# Altium schematic sheet bolid-power-dcdc-ldo.SchDoc (continued)

## Library data of the parts
C5 C7 C24 C31 = EMK107BB7225MA-T: MLCC 0603 (1608) 2.2uF 20% 16V X7R; Brand: Taiyo Yuden; Capacitance - nF: 2200 nF; Type: AECStandardQ200; Value: 2.2u; Voltage Rating DC: 16 VDC; Capacitance: 2.2 uF; Case Code - in: 0603; Case Code - mm: 1608; Category: Multilayer Ceramic Capacitors MLCC - SMD/SMT; Component Kind: Standard; ComponentLink1Description: https://www.mouser.com/datasheet/2/396/mlcc02_e-1307760.pdf; ComponentLink1URL: https://www.mouser.com/datasheet/2/396/mlcc02_e-1307760.pdf; Dielectric: X7R; Height: 0.8 mm; Length: 1.6 mm; Library Name: capacitors2.IntLib; Manufacturer: Taiyo Yuden; Manufacturer Part Number: EMK107BB7225MA-T; Maximum Operating Temperature: + 125 C; Minimum Operating Temperature: - 55 C; Minimum Order Quantity: 1; Package / Case: 0603 (1608 metric); Packaging: Cut Tape; Pricing 1: 1=0.324, 10=0.228, 100=0.129, 500=0.090, 1000=0.078, 4000=0.060, 8000=0.057, 12000=0.055, 24000=0.048 (EUR); Product: Automotive MLCCs; Product Type: Ceramic Capacitors; Reeling: True; RoHS: RoHS Compliant; RoHS - Mouser: Y; Series: M; Signal Integrity: Cap Semi; Simulation: CAP; Standard Pack Qty: 4000; Stock 1: 5687; Subcategory: Capacitors; Supplier 1: Mouser; Supplier Part Number 1: 963-EMK107BB7225MA-T; Termination: Standard; Termination Style: SMD/SMT; Tolerance: 20 %; Width: 0.8 mm
C6 C16 C26 C29 C32 = GRM155R71H104KE14D: MLCC 0402 (1005) 100nF 10% 50V X7R; Brand: Murata Electronics; Capacitance: 0.1 uF; Capacitance - pF: 100000 pF; Class: Class 2; Type: Chip Multilayer Ceramic Capacitor for General Purpose; Voltage Rating DC: 50 VDC; Capacitance - nF: 100 nF; Case Code - in: 0402; Case Code - mm: 1005; Category: Multilayer Ceramic Capacitors MLCC - SMD/SMT; Component Kind: Standard; ComponentLink1Description: https://www.mouser.com/datasheet/2/281/murata_03052018_GRM_Series_1-1310166.pdf; ComponentLink1URL: https://www.mouser.com/datasheet/2/281/murata_03052018_GRM_Series_1-1310166.pdf; Dielectric: X7R; Height: 0.5 mm; Length: 1 mm; Library Name: capacitors2.IntLib; Manufacturer: Murata Electronics; Manufacturer Part Number: GRM155R71H104KE14D; Maximum Operating Temperature: + 125 C; Minimum Operating Temperature: - 55 C; Minimum Order Quantity: 1; Package / Case: 0402 (1005 metric); Packaging: Cut Tape; Pricing 1: 1=0.090, 10=0.038, 100=0.016, 500=0.012, 1000=0.009, 2500=0.008, 5000=0.007, 10000=0.005 (EUR); Product: General Type MLCCs; Product Type: Ceramic Capacitors; Reeling: True; RoHS: RoHS Compliant; RoHS - Mouser: Y; Series: GRM; Signal Integrity: Cap Semi; Simulation: CAP; Standard Pack Qty: 10000; Stock 1: 3511637; Subcategory: Capacitors; Supplier 1: Mouser; Supplier Part Number 1: 81-GRM155R71H104KE4D; Termination: Standard; Termination Style: SMD/SMT; Tolerance: 10 %; Value Displayed: 100nF; Width: 0.5 mm
C10 = GRM155R71A474KE01D: MLCC 0402 (1005) 470nF 10% 10V X7R; Brand: Murata Electronics; Capacitance: 0.47 uF; Capacitance - pF: 470000 pF; Class: Class 2; Type: Chip Multilayer Ceramic Capacitor for General Purpose; Value: 470n; Voltage Rating DC: 10 VDC; Capacitance - nF: 470 nF; Case Code - in: 0402; Case Code - mm: 1005; Category: Multilayer Ceramic Capacitors MLCC - SMD/SMT; Component Kind: Standard; ComponentLink1Description: https://www.mouser.com/datasheet/2/281/murata_03052018_GRM_Series_1-1310166.pdf; ComponentLink1URL: https://www.mouser.com/datasheet/2/281/murata_03052018_GRM_Series_1-1310166.pdf; Dielectric: X7R; Height: 0.5 mm; Length: 1 mm; Library Name: capacitors2.IntLib; Manufacturer: Murata Electronics; Manufacturer Part Number: GRM155R71A474KE01D; Maximum Operating Temperature: + 125 C; Minimum Operating Temperature: - 55 C; Minimum Order Quantity: 1; Package / Case: 0402 (1005 metric); Packaging: Cut Tape; Pricing 1: 1=0.090, 10=0.064, 100=0.028, 500=0.020, 1000=0.015, 2500=0.014, 5000=0.013, 10000=0.010, 20000=0.009 (EUR); Product: General Type MLCCs; Product Type: Ceramic Capacitors; Reeling: True; RoHS: RoHS Compliant; RoHS - Mouser: Y; Series: GRM; Signal Integrity: Cap Semi; Simulation: CAP; Standard Pack Qty: 10000; Stock 1: 1591702; Subcategory: Capacitors; Supplier 1: Mouser; Supplier Part Number 1: 81-GRM155R71A474KE1D; Termination: Standard; Termination Style: SMD/SMT; Tolerance: 10 %; Width: 0.5 mm
C11-C15 C25 C27 C28 = C1210C106K5RACTU: MLCC 1210 (3225) 10uF 10% 50V X7R; Brand: KEMET; Class: Class 2; Voltage Rating DC: 50 VDC; Capacitance: 10 uF; Case Code - in: 1210; Case Code - mm: 3225; Category: Multilayer Ceramic Capacitors MLCC - SMD/SMT; Component Kind: Standard; ComponentLink1Description: https://www.mouser.com/datasheet/2/212/KEM_C1002_X7R_SMD-1102033.pdf; ComponentLink1URL: https://www.mouser.com/datasheet/2/212/KEM_C1002_X7R_SMD-1102033.pdf; Dielectric: X7R; Height: 2.5 mm; Length: 3.2 mm; Library Name: capacitors2.IntLib; Manufacturer: KEMET; Manufacturer Part Number: C1210C106K5RACTU; Maximum Operating Temperature: + 125 C; Minimum Operating Temperature: - 55 C; Minimum Order Quantity: 1; Package / Case: 1210 (3225 metric); Packaging: Cut Tape; Pricing 1: 1=0.984, 10=0.728, 100=0.498, 500=0.394, 1000=0.296 (USD); Product: General Type MLCCs; Product Type: Ceramic Capacitors; Reeling: True; RoHS: RoHS Compliant; RoHS - Mouser: Y; Series: SMD Comm X7R; Signal Integrity: Cap Semi; Simulation: CAP; Standard Pack Qty: 1000; Stock 1: 1149; Subcategory: Capacitors; Supplier 1: Mouser; Supplier Part Number 1: 80-C1210C106K5R; Termination: Standard; Termination Style: SMD/SMT; Tolerance: 10 %; Value Displayed: 10uF; Voltage Rating AC: -; Width: 2.5 mm
C17 C21 C22 = EMK325BJ476MM-P: MLCC 1210 (3225) 47.0uF 20% 16V X5R; Brand: Taiyo Yuden; Capacitance: 47 uF; Type: Multilayer Ceramic Capacitors; Value: 47u; Voltage Rating DC: 16 VDC; Case Code - in: 1210; Case Code - mm: 3225; Category: Multilayer Ceramic Capacitors MLCC - SMD/SMT; Component Kind: Standard; ComponentLink1Description: https://www.mouser.com/datasheet/2/396/mlcc02_e-1307760.pdf; ComponentLink1URL: https://www.mouser.com/datasheet/2/396/mlcc02_e-1307760.pdf; Dielectric: X5R; Height: 2.5 mm; Length: 3.2 mm; Library Name: capacitors2.IntLib; Manufacturer: Taiyo Yuden; Manufacturer Part Number: EMK325BJ476MM-P; Maximum Operating Temperature: + 85 C; Minimum Operating Temperature: - 55 C; Minimum Order Quantity: 1; Package / Case: 1210 (3225 metric); Packaging: Cut Tape; Pricing 1: 1=0.639, 10=0.396, 100=0.266, 500=0.224, 1000=0.180, 2000=0.167, 5000=0.156, 10000=0.135, 25000=0.131 (EUR); Product: General Type MLCCs; Product Type: Ceramic Capacitors; Reeling: True; RoHS: RoHS Compliant; RoHS - Mouser: Y; Series: M; Signal Integrity: Cap Semi; Simulation: CAP; Standard Pack Qty: 1000; Stock 1: 61399; Subcategory: Capacitors; Supplier 1: Mouser; Supplier Part Number 1: 963-EMK325BJ476MM-P; Termination: Standard; Termination Style: SMD/SMT; Tolerance: 20 %; Width: 2.5 mm
C18 = GCM1555C1H220JA16D: MLCC 0402 (1005) 22pF 5% 50V C0G (NP0); Brand: Murata Electronics; Class: Class 1; Qualification: AEC-Q200; Type: Chip Multilayer Ceramic Capacitors for Automotive; Value: 22p; Voltage Rating DC: 50 VDC; Capacitance: 22 pF; Case Code - in: 0402; Case Code - mm: 1005; Category: Multilayer Ceramic Capacitors MLCC - SMD/SMT; Component Kind: Standard; ComponentLink1Description: https://www.mouser.com/datasheet/2/281/GCM1555C1H220JA16_01-1964700.pdf; ComponentLink1URL: https://www.mouser.com/datasheet/2/281/GCM1555C1H220JA16_01-1964700.pdf; Dielectric: C0G (NP0); Height: 0.5 mm; Length: 1 mm; Library Name: capacitors2.IntLib; Manufacturer: Murata Electronics; Manufacturer Part Number: GCM1555C1H220JA16D; Maximum Operating Temperature: + 125 C; Minimum Operating Temperature: - 55 C; Minimum Order Quantity: 1; Package / Case: 0402 (1005 metric); Packaging: Cut Tape; Pricing 1: 1=0.085, 10=0.026, 100=0.022, 500=0.015, 1000=0.013, 2500=0.011, 5000=0.010, 10000=0.008 (EUR); Product: Automotive MLCCs; Product Type: Ceramic Capacitors; Reeling: True; RoHS: RoHS Compliant; RoHS - Mouser: Y; Series: GCM; Signal Integrity: Cap Semi; Simulation: CAP; Standard Pack Qty: 10000; Stock 1: 193169; Subcategory: Capacitors; Supplier 1: Mouser; Supplier Part Number 1: 81-GCM1555C1H220JA6D; Termination: Standard; Termination Style: SMD/SMT; Tolerance: 5 %; Voltage Rating AC: -; Width: 0.5 mm
C19 C20 = T495X476K035ATE300: TANT 2917 (7343) 47uF 10% 35V Tantalum Kemet Case X; Mfr Case Code: X Case; ESR: 300 mOhms; Type: SMD Automotive Grade Surge Robust Low ESR; Voltage Rating DC: 35 VDC; Brand: KEMET; Capacitance: 47 uF; Case Code - in: 2917; Case Code - mm: 7343; Category: Tantalum Capacitors - Solid SMD; Component Kind: Standard; ComponentLink1Description: https://www.mouser.com/datasheet/2/212/KEM_T2009_T495-1092841.pdf; ComponentLink1URL: https://www.mouser.com/datasheet/2/212/KEM_T2009_T495-1092841.pdf; Height: 4 mm; Length: 7.3 mm; Library Name: capacitors2.IntLib; Manufacturer: KEMET; Manufacturer Part Number: T495X476K035ATE300; Maximum Operating Temperature: + 125 C; Minimum Operating Temperature: - 55 C; Minimum Order Quantity: 1; Packaging: Cut Tape; Pricing 1: 1=2.42, 10=1.94, 100=1.46, 500=0.989, 1000=0.897, 2500=0.884 (USD); Product: Tantalum Solid Low ESR Automotive Grade; Product Type: Tantalum Capacitors; Reeling: True; RoHS: RoHS Compliant; RoHS - Mouser: Y; Series: T495; Signal Integrity: Cap Semi; Simulation: CAP; Standard Pack Qty: 500; Stock 1: 39161; Subcategory: Capacitors; Supplier 1: Mouser; Supplier Part Number 1: 80-T495X476K35ATE300; Termination Style: SMD/SMT; Tolerance: 10 %; Value: 47uF; Width: 4.3 mm
C23 = C1005X5R1A475M050BC: MLCC 0402 (1005) 4.7uF 20% 10V X5R; Brand: TDK; Capacitance - nF: 4700 nF; Class: Class2; Type: Multilayer Ceramic Chip Capacitor; Value: 4.7u; Voltage Rating DC: 10 VDC; Capacitance: 4.7 uF; Case Code - in: 0402; Case Code - mm: 1005; Category: Multilayer Ceramic Capacitors MLCC - SMD/SMT; Component Kind: Standard; Dielectric: X5R; Height: 0.5 mm; Length: 1 mm; Library Name: capacitors2.IntLib; Manufacturer: TDK; Manufacturer Part Number: C1005X5R1A475M050BC; Maximum Operating Temperature: + 85 C; Minimum Operating Temperature: - 55 C; Minimum Order Quantity: 1; Packaging: Cut Tape; Pricing 1: 1=0.398, 10=0.234, 100=0.170, 500=0.130, 1000=0.109, 2500=0.103, 5000=0.100, 10000=0.097 (EUR); Product: General Type MLCCs; Product Type: Ceramic Capacitors; Reeling: True; RoHS: RoHS Compliant; RoHS - Mouser: Y; Series: C; Signal Integrity: Cap Semi; Simulation: CAP; Standard Pack Qty: 10000; Stock 1: 236282; Subcategory: Capacitors; Supplier 1: Mouser; Supplier Part Number 1: 810-C1005X5R1A475M; Termination: Standard; Termination Style: SMD/SMT; Tolerance: 20 %; Voltage Rating AC: -; Width: 0.5 mm
C30 = TMK316AB7106KL-T: MLCC 1206 (3216) 10uF 10% 25V X7R; Brand: Taiyo Yuden; Class: Class 2; Type: General Purpose; Value: 10u; Voltage Rating DC: 25 VDC; Capacitance: 10 uF; Case Code - in: 1206; Case Code - mm: 3216; Category: Multilayer Ceramic Capacitors MLCC - SMD/SMT; Component Kind: Standard; ComponentLink1Description: https://www.mouser.com/datasheet/2/396/mlcc02_e-1307760.pdf; ComponentLink1URL: https://www.mouser.com/datasheet/2/396/mlcc02_e-1307760.pdf; Diameter: -; Dielectric: X7R; Height: 1.6 mm; Length: 3.2 mm; Library Name: capacitors2.IntLib; Manufacturer: Taiyo Yuden; Manufacturer Part Number: TMK316AB7106KL-T; Maximum Operating Temperature: + 125 C; Minimum Operating Temperature: - 55 C; Minimum Order Quantity: 1; Package / Case: 1206 (3216 metric); Packaging: Cut Tape; Pricing 1: 1=0.288, 10=0.130, 100=0.087, 500=0.077, 1000=0.068, 2000=0.056 (EUR); Product: General Type MLCCs; Product Type: Ceramic Capacitors; Reeling: True; RoHS: RoHS Compliant; RoHS - Mouser: Y; Series: M; Signal Integrity: Cap Semi; Simulation: CAP; Standard Pack Qty: 2000; Stock 1: 162457; Subcategory: Capacitors; Supplier 1: Mouser; Supplier Part Number 1: 963-TMK316AB7106KL-T; Termination: Standard; Termination Style: SMD/SMT; Tolerance: 10 %; Width: 1.6 mm
C33-C37 = GRM21BR71A106KA73K: MLCC 0805 (2012) 10uF 10% 10V X7R; Brand: Murata Electronics; Class: Class 2; Type: Chip Multilayer Ceramic Capacitor for General Purpose; Value: 10u; Voltage Rating DC: 10 VDC; Capacitance: 10 uF; Case Code - in: 0805; Case Code - mm: 2012; Category: Multilayer Ceramic Capacitors MLCC - SMD/SMT; Component Kind: Standard; ComponentLink1Description: https://www.mouser.com/datasheet/2/281/murata_03052018_GRM_Series_1-1310166.pdf; ComponentLink1URL: https://www.mouser.com/datasheet/2/281/murata_03052018_GRM_Series_1-1310166.pdf; Dielectric: X7R; Height: 1.25 mm; Length: 2 mm; Library Name: capacitors2.IntLib; Manufacturer: Murata Electronics; Manufacturer Part Number: GRM21BR71A106KA73K; Maximum Operating Temperature: + 125 C; Minimum Operating Temperature: - 55 C; Minimum Order Quantity: 1; Package / Case: 0805 (2012 metric); Packaging: Cut Tape; Pricing 1: 1=0.207, 10=0.144, 100=0.072, 500=0.050, 1000=0.043, 2500=0.040, 5000=0.036, 10000=0.030, 20000=0.028 (EUR); Product: General Type MLCCs; Product Type: Ceramic Capacitors; Reeling: True; RoHS: RoHS Compliant; RoHS - Mouser: Y; Series: GRM; Signal Integrity: Cap Semi; Simulation: CAP; Standard Pack Qty: 10000; Stock 1: 108729; Subcategory: Capacitors; Supplier 1: Mouser; Supplier Part Number 1: 81-GRM21BR71A106KA3K; Termination: Standard; Termination Style: SMD/SMT; Tolerance: 10 %; Width: 1.25 mm
D2-D5 = 150060GS75000: LED 0603 (1608) Green; Brand: Wurth Elektronik; If - Forward Current: 20 mA; Lens Color/Style: Water Clear; Lens Shape: Rectangular; Luminous Intensity: 430 mcd; Peak Wavelength: 515 nm; Power Rating: 105 mW; Vf - Forward Voltage: 3.2 V; Viewing Angle: 140 deg; Vr - Reverse Voltage: 5 V; Wavelength/Color Temperature: 520 nm; Category: Standard LEDs - SMD; Component Kind: Standard; ComponentLink1Description: https://www.mouser.com/datasheet/2/445/150060GS75000-368921.pdf; ComponentLink1URL: https://www.mouser.com/datasheet/2/445/150060GS75000-368921.pdf; Height: 0.7 mm; Length: 1.6 mm; Lens Dimensions: 1.1 mm x 0.8 mm; Lens Transparency: Water Clear; Library Name: semiconductors2.IntLib; Manufacturer: Wurth Elektronik; Manufacturer Part Number: 150060GS75000; Maximum Operating Temperature: + 85 C; Minimum Operating Temperature: - 40 C; Minimum Order Quantity: 1; Moisture Sensitive: Yes; Mounting Style: SMD/SMT; Package / Case: 0603 (1608 metric); Packaging: Cut Tape; Pricing 1: 1=0.130, 10=0.126, 50=0.115, 100=0.099, 500=0.085, 4000=0.085 (EUR); Product: Green LEDs; Product Type: LED - Standard; Reeling: True; RoHS: RoHS Compliant; RoHS - Mouser: Y; Series: WL-SMCW; Signal Integrity: Diode; Simulation: DIODE; Standard Pack Qty: 4000; Stock 1: 17312; Subcategory: LEDs; Supplier 1: Mouser; Supplier Part Number 1: 710-150060GS75000; Width: 0.8 mm
D17 = VSSAF5L45HM3_A/H: Schottky Rectifier Diode, 5A, Do-221Ac-2 Rohs Compliant: Yes |Vishay VSSAF5L45HM3_A/H; Manufacturer: Vishay; Category: Rectifier Diodes; Component Kind: Standard; Library Name: semiconductors2.IntLib; Manufacturer Part Number: VSSAF5L45HM3_A/H; Pricing 1: 1=0,45, 10=0,303, 50=0,303, 100=0,205, 1000=0,142, 10000=0,115 (USD); RoHS: No; RoHS Compliant: Yes; Signal Integrity: Diode; Simulation: DIODE; Stock 1: 4251; Supplier 1: Mouser; Supplier Part Number 1: 78-VSSAF5L45HM3_A/H
D18 = BZX84-B3V6,215: Zener Diode Single 3.6V 2% 90Ohm 250mW Automotive 3-Pin SOT-23 T/R; Manufacturer: NXP Semiconductors; Continuous Drain Current (ID): -150mA; Drain to Source Voltage (Vdss): -50V; ESD Protection: No; Impedance: 90R; Max Reverse Leakage Current: 5uA; Test Current: 5mA; Threshold Voltage: -1.6V; Case/Package: TO-236-3; Category: Uncategorized; Component Kind: Standard; ComponentLink1Description: https://datasheet.ciiva.com/10645/bzx84-series-10645035.pdf?src-supplier=Avnet; ComponentLink1URL: https://datasheet.ciiva.com/10645/bzx84-series-10645035.pdf?src-supplier=Avnet; ComponentLink2Description: https://datasheet.ciiva.com/890/bzx84-ser-890061.pdf?src-supplier=Digi-Key; ComponentLink2URL: https://datasheet.ciiva.com/890/bzx84-ser-890061.pdf?src-supplier=Digi-Key; ComponentLink3Description: https://datasheet.ciiva.com/890/bzx84-ser-890063.pdf?src-supplier=Digi-Key; ComponentLink3URL: https://datasheet.ciiva.com/890/bzx84-ser-890063.pdf?src-supplier=Digi-Key; ComponentLink4Description: https://datasheet.ciiva.com/890/bzx84-series-890064.pdf?src-supplier=Digi-Key; ComponentLink4URL: https://datasheet.ciiva.com/890/bzx84-series-890064.pdf?src-supplier=Digi-Key; ComponentLink5Description: https://datasheet.ciiva.com/8347/bzx84-series-8347141.pdf?src-supplier=Avnet; ComponentLink5URL: https://datasheet.ciiva.com/8347/bzx84-series-8347141.pdf?src-supplier=Avnet; ComponentLink6Description: https://datasheet.ciiva.com/17265/bzx84-series-17265675.pdf?; ComponentLink6URL: https://datasheet.ciiva.com/17265/bzx84-series-17265675.pdf?; Contact Plating: Tin; Element Configuration: Single; Lead Free: Lead Free; Library Name: semiconductors2.IntLib; Manufacturer Part Number: BZX84-B3V6,215; Max Operating Temperature: 150°C; Max Power Dissipation: 250mW; Min Operating Temperature: -65°C; Mount: Surface Mount; Number of Pins: 3; Package Quantity: 1; Packaging: Cut Tape; Power Dissipation: 250mW; Radiation Hardening: No; Reach SVHC Compliant: Yes; RoHS: Yes; RoHS Compliant: Yes; Supplier 1: Mouser; Supplier Part Number 1: 771-BZX84-B3V6215; Tolerance: 2%; Voltage Tolerance: 2%
D19 D21 D22 = MBR0530T3G: ON Semi MBR0530T3G; SMT Schottky Diode; 30V 500mA; 2-Pin SOD-123; Manufacturer: ON Semiconductor; Category: Schottky Diodes; Component Kind: Standard; ComponentLink1Description: https://datasheet.ciiva.com/6381/mbr0530t1-d-6381904.pdf?src-supplier=Digikey; ComponentLink1URL: https://datasheet.ciiva.com/6381/mbr0530t1-d-6381904.pdf?src-supplier=Digikey; ComponentLink2Description: https://datasheet.ciiva.com/7962/85890-7962703.pdf?src-supplier=Element14; ComponentLink2URL: https://datasheet.ciiva.com/7962/85890-7962703.pdf?src-supplier=Element14; ComponentLink3Description: https://datasheet.ciiva.com/8294/mbr0530t1-d-8294572.pdf?src-supplier=Avnet; ComponentLink3URL: https://datasheet.ciiva.com/8294/mbr0530t1-d-8294572.pdf?src-supplier=Avnet; ComponentLink4Description: https://datasheet.ciiva.com/15144/getdatasheetpartid-86762-15144599.pdf?src-supplier=Verical; ComponentLink4URL: https://datasheet.ciiva.com/15144/getdatasheetpartid-86762-15144599.pdf?src-supplier=Verical; ComponentLink5Description: https://datasheet.ciiva.com/6381/mbr0530t1-d-6381902.pdf?src-supplier=Digikey; ComponentLink5URL: https://datasheet.ciiva.com/6381/mbr0530t1-d-6381902.pdf?src-supplier=Digikey; Library Name: semiconductors2.IntLib; Manufacturer Part Number: MBR0530T3G; Pricing 1: 1=0,19, 10=0,126, 50=0,126, 100=0,065, 1000=0,053, 10000=0,041 (USD); RoHS: Yes; Signal Integrity: Diode; Simulation: DIODE; Stock 1: 167531; Supplier 1: Mouser; Supplier Part Number 1: 863-MBR0530T3G
D20 = BZX84-C12,215: Diodes - Zener - Single Diode SOT-23 (TO-236AB) 250mW 12V Surface Mount; Manufacturer: Nexperia; Forward Current: 10mA; Max Reverse Leakage Current: 100nA; Reverse Voltage: 8V; Category: Zener Diodes; Component Kind: Standard; ComponentLink1Description: http://www.farnell.com/datasheets/2242065.pdf?src-supplier=Farnell; ComponentLink1URL: http://www.farnell.com/datasheets/2242065.pdf?src-supplier=Farnell; ComponentLink2Description: http://www.farnell.com/datasheets/1808429.pdf?src-supplier=Farnell; ComponentLink2URL: http://www.farnell.com/datasheets/1808429.pdf?src-supplier=Farnell; ComponentLink3Description: http://www.farnell.com/datasheets/1808429.pdf?src-supplier=Newark; ComponentLink3URL: http://www.farnell.com/datasheets/1808429.pdf?src-supplier=Newark; ComponentLink4Description: https://datasheet.octopart.com/BZX84-C12%2C215-Nexperia-datasheet-14490340.pdf?src-supplier=Element14; ComponentLink4URL: https://datasheet.octopart.com/BZX84-C12%2C215-Nexperia-datasheet-14490340.pdf?src-supplier=Element14; ComponentLink5Description: https://datasheet.octopart.com/BZX84-C12%2C215-Nexperia-datasheet-24570111.pdf?src-supplier=Newark; ComponentLink5URL: https://datasheet.octopart.com/BZX84-C12%2C215-Nexperia-datasheet-24570111.pdf?src-supplier=Newark; ComponentLink6Description: https://datasheet.octopart.com/BZX84-C12%2C215-Nexperia-datasheet-116120567.pdf?src-supplier=Future+Electronics; ComponentLink6URL: https://datasheet.octopart.com/BZX84-C12%2C215-Nexperia-datasheet-116120567.pdf?src-supplier=Future+Electronics; ComponentLink7Description: https://assets.nexperia.com/documents/data-sheet/BZX84_SER.pdf?src-supplier=Digikey; ComponentLink7URL: https://assets.nexperia.com/documents/data-sheet/BZX84_SER.pdf?src-supplier=Digikey; ComponentLink8Description: https://datasheet.octopart.com/BZX84-C12%2C215-Nexperia-datasheet-81885907.pdf?src-supplier=TME; ComponentLink8URL: https://datasheet.octopart.com/BZX84-C12%2C215-Nexperia-datasheet-81885907.pdf?src-supplier=TME; Height: 1.1mm; Library Name: semiconductors2.IntLib; Manufacturer Part Number: BZX84-C12,215; Max Operating Temperature: 150°C; Min Operating Temperature: -65°C; Number of Pins: 3NUMBER; Packaging: 13Packaging; Power Dissipation: 250mW; Pricing 1: 1=0,1, 10=0,05, 50=0,05, 100=0,029, 1000=0,024, 10000=0,015 (USD); RoHS: Yes; Stock 1: 259413; Supplier 1: Mouser; Supplier Part Number 1: 771-BZX84-C12-T/R
D23 = BZX84-B5V6,215: Zener Diode, 5.6V V(Z), 2%, 0.25W, Silicon, Unidirectional, TO-236AB; Manufacturer: Nexperia; Category: Zener Diodes; Component Kind: Standard; ComponentLink1Description: https://datasheet.octopart.com/BZX84-B5V6%2C215-Nexperia-datasheet-24570111.pdf?src-supplier=Newark; ComponentLink1URL: https://datasheet.octopart.com/BZX84-B5V6%2C215-Nexperia-datasheet-24570111.pdf?src-supplier=Newark; ComponentLink2Description: https://datasheet.octopart.com/BZX84-B5V6%2C215-NXP-Semiconductors-datasheet-14490340.pdf?src-supplier=Element14; ComponentLink2URL: https://datasheet.octopart.com/BZX84-B5V6%2C215-NXP-Semiconductors-datasheet-14490340.pdf?src-supplier=Element14; ComponentLink3Description: https://datasheet.octopart.com/BZX84-B5V6%2C215-Nexperia-datasheet-81885907.pdf?src-supplier=TME; ComponentLink3URL: https://datasheet.octopart.com/BZX84-B5V6%2C215-Nexperia-datasheet-81885907.pdf?src-supplier=TME; Library Name: semiconductors2.IntLib; Manufacturer Part Number: BZX84-B5V6,215; Number of Pins: 3NUMBER; Pricing 1: 1=0,12, 10=0,079, 50=0,079, 100=0,041, 1000=0,036, 10000=0,018 (USD); RoHS: Yes; Stock 1: 80701; Supplier 1: Mouser; Supplier Part Number 1: 771-BZX84-B5V6-T/R
F1 = SR010-15: PTC Fuse Hold Current 0.10A, Trip Current 0.30A VMax 15V; Manufacturer: Excel Cell; Component Kind: Standard; Library Name: misc2.IntLib; Manufacturer Part Number: SR010-15; RoHS: No; Stock 1: 5574; Supplier 1: TME; Supplier Part Number 1: SR010-15
L2 = DFE252012F-R47M=P2: Inductor Power Chip Shielded Wirewound 0.47uH 20% 1MHz Metal 4.9A 0.023Ohm DCR 1008 T/R; Manufacturer: Murata; Series Resistance: 23mR; Category: Fixed Inductors; Component Kind: Standard; ComponentLink1Description: http://www.murata.com/~/media/webrenewal/products/inductor/chip/tokoproducts/wirewoundmetalalloychiptype/m_dfe252012f.ashx?src-supplier=Digikey; ComponentLink1URL: http://www.murata.com/~/media/webrenewal/products/inductor/chip/tokoproducts/wirewoundmetalalloychiptype/m_dfe252012f.ashx?src-supplier=Digikey; ComponentLink2Description: https://www.murata.com/~/media/webrenewal/products/inductor/chip/tokoproducts/wirewoundmetalalloychiptype/m_dfe252012f.ashx?src-supplier=Digikey; ComponentLink2URL: https://www.murata.com/~/media/webrenewal/products/inductor/chip/tokoproducts/wirewoundmetalalloychiptype/m_dfe252012f.ashx?src-supplier=Digikey; ComponentLink3Description: https://datasheet.octopart.com/DFE252012F-R47M%3DP2-Murata-datasheet-141944277.pdf?src-supplier=Arrow.cn; ComponentLink3URL: https://datasheet.octopart.com/DFE252012F-R47M%3DP2-Murata-datasheet-141944277.pdf?src-supplier=Arrow.cn; Height: 1.2mm; Inductance Tolerance: 20%; Library Name: inductors2.IntLib; Manufacturer Part Number: DFE252012F-R47M=P2; Pricing 1: 1=0,31, 10=0,181, 50=0,181, 100=0,158, 1000=0,143, 10000=0,104 (USD); RMS Current (Irms): 4.9A; RoHS: Yes; Signal Integrity: Inductor; Simulation: INDUCTOR; Stock 1: 91663; Supplier 1: Mouser; Supplier Part Number 1: 81-DFE252012F-R47MP2
L3 = XAL5050-822MEB: Fixed Inductors 8.2uH 20% 6.1A 34.95mOhms AEC-Q200; Brand: Coilcraft; Core Material: Composite; Self Resonant Frequency: 18 MHz; Shielding: Shielded; Test Frequency: 100 kHz; Application: Power; Category: Fixed Inductors; Component Kind: Standard; ComponentLink1Description: https://www.mouser.com/datasheet/2/597/xal50xx-270657.pdf; ComponentLink1URL: https://www.mouser.com/datasheet/2/597/xal50xx-270657.pdf; Height: 5.1 mm; Inductance: 8.2 uH; Length: 5.68 mm; Library Name: inductors2.IntLib; Manufacturer: Coilcraft; Manufacturer Part Number: XAL5050-822MEB; Maximum DC Current: 6.1 A; Maximum DC Resistance: 34.95 mOhms; Maximum Operating Temperature: + 125 C; Minimum Operating Temperature: - 40 C; Minimum Order Quantity: 1; Mounting Style: PCB Mount; Packaging: Cut Tape; Pricing 1: 1=2.49, 10=2.23, 50=2.13, 250=2.13, 500=1.40, 1000=1.29, 2000=1.20 (EUR); Product: Power Inductors; Product Type: Fixed Inductors; Qualification: AEC-Q200; Reeling: True; RoHS: RoHS Compliant; RoHS - Mouser: Y; Series: XAL5050; Signal Integrity: Inductor Iron; Simulation: INDUCTOR; Standard Pack Qty: 250; Stock 1: 693; Subcategory: Inductors, Chokes & Coils; Supplier 1: Mouser; Supplier Part Number 1: 994-XAL5050-822MEB; Termination: -; Termination Style: SMD/SMT; Tolerance: 20 %; Width: 5.48 mm
R8 R16 R21 = RC0603FR-070RL: RES 0603 (1608) 0.00 ohm 1% 0.100W 75V Thick Film; Brand: Yageo; Power Rating: 100 mW, 200 mW; Resistance: 0 Ohms; Application: High Reliability; Case Code - in: 0603; Case Code - mm: 1608; Category: Thick Film Resistors - SMD; Component Kind: Standard; ComponentLink1Description: https://www.mouser.com/datasheet/2/447/PYu-RC_Group_51_RoHS_L_10-1527934.pdf; ComponentLink1URL: https://www.mouser.com/datasheet/2/447/PYu-RC_Group_51_RoHS_L_10-1527934.pdf; Features: Zero Ohm Jumpers; Height: 0.45 mm; Length: 1.6 mm; Library Name: resistors2.IntLib; Manufacturer: Yageo; Manufacturer Part Number: RC0603FR-070RL; Maximum Operating Temperature: + 155 C; Minimum Operating Temperature: - 55 C; Minimum Order Quantity: 1; Mounting Style: PCB Mount; Package/Case: -; Packaging: Cut Tape; Pricing 1: 1=0.098, 10=0.015, 100=0.005, 1000=0.003, 5000=0.003 (USD); Product: Thick Film Resistors Zero-Ohm; Product Type: Thick Film Resistors; Reeling: True; RoHS: RoHS Compliant By Exemption; RoHS - Mouser: E; Series: RC; Signal Integrity: Res; Simulation: RESISTOR; Standard Pack Qty: 5000; Stock 1: 1394667; Subcategory: Resistors; Supplier 1: Mouser; Supplier Part Number 1: 603-RC0603FR-070RL; Technology: Thick Film; Termination Style: SMD/SMT; Tolerance: 1 %; Voltage Rating: 75 V; Width: 0.8 mm
R9 = ERJ-3RSFR10V: RES 0603 (1608) 0.10 ohm 1% 0.100W Thick Film; Brand: Panasonic; Power Rating: 100 mW (1/10 W); Qualification: AEC-Q200; Resistance: 100 mOhms; Temperature Coefficient: 200 PPM / C; Application: Current Sense; Case Code - in: 0603; Case Code - mm: 1608; Category: Current Sense Resistors - SMD; Component Kind: Standard; ComponentLink1Description: https://www.mouser.com/datasheet/2/315/AOA0000C313-1141758.pdf; ComponentLink1URL: https://www.mouser.com/datasheet/2/315/AOA0000C313-1141758.pdf; Features: -; Height: 0.45 mm; Length: 1.6 mm; Library Name: resistors2.IntLib; Manufacturer: Panasonic; Manufacturer Part Number: ERJ-3RSFR10V; Maximum Operating Temperature: + 125 C; Minimum Operating Temperature: - 55 C; Minimum Order Quantity: 1; Mounting Style: PCB Mount; Packaging: Cut Tape; Pricing 1: 1=0.279, 10=0.124, 100=0.055, 1000=0.038, 5000=0.029 (EUR); Product: Thick Film Resistors SMD; Product Type: Current Sense Resistors; Reeling: True; RoHS: RoHS Compliant By Exemption; RoHS - Mouser: E; Series: ERJ-xRQ/S; Signal Integrity: Res; Simulation: RESISTOR; Standard Pack Qty: 5000; Stock 1: 97836; Subcategory: Resistors; Supplier 1: Mouser; Supplier Part Number 1: 667-ERJ-3RSFR10V; Technology: Thick Film; Termination: 2 Terminal; Termination Style: SMD/SMT; Tolerance: 1 %; Type: Low Resistance; Width: 0.8 mm
R10 = AC0402FR-071ML: RES 0402 (1005) 1.00M 1% 0.0625W 50V Thick Film; Brand: YAGEO; Power Rating: 62.5 mW (1/16 W); Qualification: AEC-Q200; Resistance: 1 MOhms; Temperature Coefficient: 100 PPM / C; Application: Automotive Grade; Case Code - in: 0402; Case Code - mm: 1005; Category: Thick Film Resistors - SMD; Component Kind: Standard; ComponentLink1Description: https://www.mouser.com/datasheet/2/447/Yageo_PYu_AC_51_RoHS_L_7_1714230-1874691.pdf; ComponentLink1URL: https://www.mouser.com/datasheet/2/447/Yageo_PYu_AC_51_RoHS_L_7_1714230-1874691.pdf; Height: 0.32 mm; Length: 1 mm; Library Name: resistors2.IntLib; Manufacturer: YAGEO; Manufacturer Part Number: AC0402FR-071ML; Maximum Operating Temperature: + 155 C; Minimum Operating Temperature: - 55 C; Minimum Order Quantity: 1; Mounting Style: PCB Mount; Packaging: Cut Tape; Pricing 1: 1=0.085, 10=0.019, 100=0.008, 1000=0.004, 2500=0.003, 10000=0.003 (EUR); Product: Thick Film Resistors General Purpose; Product Type: Thick Film Resistors; Reeling: True; RoHS: RoHS Compliant By Exemption; RoHS - Mouser: E; Series: AC; Signal Integrity: Res; Simulation: RESISTOR; Standard Pack Qty: 10000; Stock 1: 142366; Subcategory: Resistors; Supplier 1: Mouser; Supplier Part Number 1: 603-AC0402FR-071ML; Technology: Thick Film; Termination Style: SMD/SMT; Tolerance: 1 %; Type: General Purpose Resistor; Voltage Rating: 50 V; Width: 0.5 mm
R11 R13 R18 R23 = RC0402FR-07100KL: RES 0402 (1005) 100.0k 1% 0.0625W 50V Thick Film; Brand: Yageo; Power Rating: 62.5 mW, 125 mW; Resistance: 100 kOhms; Temperature Coefficient: 100 PPM / C; Application: High Reliability; Case Code - in: 0402; Case Code - mm: 1005; Category: Thick Film Resistors - SMD; Component Kind: Standard; ComponentLink1Description: https://www.mouser.com/datasheet/2/447/PYu-RC_Group_51_RoHS_L_10-1664068.pdf; ComponentLink1URL: https://www.mouser.com/datasheet/2/447/PYu-RC_Group_51_RoHS_L_10-1664068.pdf; Features: -; Height: 0.35 mm; Length: 1 mm; Library Name: resistors2.IntLib; Manufacturer: Yageo; Manufacturer Part Number: RC0402FR-07100KL; Maximum Operating Temperature: + 155 C; Minimum Operating Temperature: - 55 C; Minimum Order Quantity: 1; Mounting Style: PCB Mount; Package / Case: -; Packaging: Cut Tape; Pricing 1: 1=0.090, 10=0.011, 100=0.004, 1000=0.003, 10000=0.003 (EUR); Product: Thick Film Resistors SMD; Product Type: Thick Film Resistors; Reeling: True; RoHS: RoHS Compliant; RoHS - Mouser: Y; Series: RC; Signal Integrity: Res; Simulation: RESISTOR; Standard Pack Qty: 10000; Stock 1: 2491111; Subcategory: Resistors; Supplier 1: Mouser; Supplier Part Number 1: 603-RC0402FR-07100KL; Technology: Thick Film; Termination Style: SMD/SMT; Tolerance: 1 %; Type: General Purpose; Voltage Rating: 50 V; Width: 0.5 mm
R12 R19 R24 R26 = RC0402FR-0710KL: RES 0402 (1005) 10.0k 1% 0.0625W 50V Thick Film; Brand: Yageo; Power Rating: 62.5 mW, 125 mW; Resistance: 10 kOhms; Temperature Coefficient: 100 PPM / C; Application: High Reliability; Case Code - in: 0402; Case Code - mm: 1005; Category: Thick Film Resistors - SMD; Component Kind: Standard; ComponentLink1Description: https://www.mouser.com/datasheet/2/447/PYu-RC_Group_51_RoHS_L_10-1664068.pdf; ComponentLink1URL: https://www.mouser.com/datasheet/2/447/PYu-RC_Group_51_RoHS_L_10-1664068.pdf; Features: -; Height: 0.35 mm; Length: 1 mm; Library Name: resistors2.IntLib; Manufacturer: Yageo; Manufacturer Part Number: RC0402FR-0710KL; Maximum Operating Temperature: + 155 C; Minimum Operating Temperature: - 55 C; Minimum Order Quantity: 1; Mounting Style: PCB Mount; Package / Case: -; Packaging: Cut Tape; Pricing 1: 1=0.090, 10=0.011, 100=0.004, 1000=0.003, 10000=0.003 (EUR); Product: Thick Film Resistors SMD; Product Type: Thick Film Resistors; Reeling: True; RoHS: RoHS Compliant; RoHS - Mouser: Y; Series: RC; Signal Integrity: Res; Simulation: RESISTOR; Standard Pack Qty: 10000; Stock 1: 20942447; Subcategory: Resistors; Supplier 1: Mouser; Supplier Part Number 1: 603-RC0402FR-0710KL; Technology: Thick Film; Termination Style: SMD/SMT; Tolerance: 1 %; Type: General Purpose; Voltage Rating: 50 V; Width: 0.5 mm
R14 = ERJ-2RKF4423X: RES 0402 (1005) 442.0k 1% 0.100W 50V Thick Film; Brand: Panasonic; Power Rating: 100 mW (1/10 W); Qualification: AEC-Q200; Resistance: 442 kOhms; Temperature Coefficient: 100 PPM / C; Application: Automotive Grade; Case Code - in: 0402; Case Code - mm: 1005; Category: Thick Film Resistors - SMD; Component Kind: Standard; ComponentLink1Description: https://www.mouser.com/datasheet/2/315/AOA0000C304-1149620.pdf; ComponentLink1URL: https://www.mouser.com/datasheet/2/315/AOA0000C304-1149620.pdf; Features: Precision Resistors; Height: 0.35 mm; Length: 1 mm; Library Name: resistors2.IntLib; Manufacturer: Panasonic; Manufacturer Part Number: ERJ-2RKF4423X; Maximum Operating Temperature: + 155 C; Minimum Operating Temperature: - 55 C; Minimum Order Quantity: 1; Mounting Style: PCB Mount; Packaging: Cut Tape; Pricing 1: 1=0.085, 10=0.025, 100=0.010, 1000=0.005, 2500=0.004, 5000=0.003, 10000=0.003 (EUR); Product: Thick Film Resistors SMD; Product Type: Thick Film Resistors; Reeling: True; RoHS: RoHS Compliant By Exemption; RoHS - Mouser: E; Series: ERJ-2Rx; Signal Integrity: Res; Simulation: RESISTOR; Standard Pack Qty: 10000; Stock 1: 56067; Subcategory: Resistors; Supplier 1: Mouser; Supplier Part Number 1: 667-ERJ-2RKF4423X; Technology: Thick Film; Termination Style: SMD/SMT; Tolerance: 1 %; Voltage Rating: 50 V; Width: 0.5 mm
R15 = RC0402FR-0790K9L: RES 0402 (1005) 90.9k 1% 0.0625W 50V Thick Film; Brand: YAGEO; Power Rating: 62.5 mW (1/16 W); Resistance: 90.9 kOhms; Temperature Coefficient: 100 PPM / C; Application: High Reliability; Case Code - in: 0402; Case Code - mm: 1005; Category: Thick Film Resistors - SMD; Component Kind: Standard; ComponentLink1Description: https://www.mouser.com/datasheet/2/447/PYu_RC_Group_51_RoHS_L_10-1664068.pdf; ComponentLink1URL: https://www.mouser.com/datasheet/2/447/PYu_RC_Group_51_RoHS_L_10-1664068.pdf; Features: -; Height: 0.35 mm; Length: 1 mm; Library Name: resistors2.IntLib; Manufacturer: YAGEO; Manufacturer Part Number: RC0402FR-0790K9L; Maximum Operating Temperature: + 155 C; Minimum Operating Temperature: - 55 C; Minimum Order Quantity: 1; Mounting Style: PCB Mount; Packaging: Cut Tape; Pricing 1: 1=0.088, 10=0.014, 100=0.006, 1000=0.003, 10000=0.001 (EUR); Product: Thick Film Resistors SMD; Product Type: Thick Film Resistors; Reeling: True; RoHS: RoHS Compliant By Exemption; RoHS - Mouser: E; Series: RC; Signal Integrity: Res; Simulation: RESISTOR; Standard Pack Qty: 10000; Stock 1: 41956; Subcategory: Resistors; Supplier 1: Mouser; Supplier Part Number 1: 603-RC0402FR-0790K9L; Technology: Thick Film; Termination Style: SMD/SMT; Tolerance: 1 %; Type: General Purpose; Voltage Rating: 50 V; Width: 0.5 mm
R17 = AC0402FR-07162KL: RES 0402 (1005) 162.0k 1% 0.125W 50V Thick Film; Manufacturer: Yageo; Max Power Dissipation: 63mW; Resistance: 162kR; Temperature Coefficient: 100ppm/°C; Case/Package: 0402; Category: Chip SMD Resistors; Component Kind: Standard; ComponentLink1Description: http://www.yageo.com/NewPortal/yageodocoutput?fileName=/pdf/R-Chip/PYu-AC_51_RoHS_L_6.pdf&src-supplier=Digikey; ComponentLink1URL: http://www.yageo.com/NewPortal/yageodocoutput?fileName=/pdf/R-Chip/PYu-AC_51_RoHS_L_6.pdf&src-supplier=Digikey; Features: Moisture Resistant,Automotive AEC-Q200; Height: 0.015inch; Length: 0.039inch; Library Name: resistors2.IntLib; Manufacturer Part Number: AC0402FR-07162KL; Max Operating Temperature: 155°C; Min Operating Temperature: -55°C; Number of Terminations: 2; Packaging: Tape and Reel; Pricing 1: 1=0,1, 10=0,012, 50=0,012, 100=0,007, 1000=0,005, 10000=0,003 (USD); RoHS: Yes; RoHS Compliant: Yes; Series: AC; Signal Integrity: Res; Simulation: RESISTOR; Stock 1: 32447; Supplier 1: Mouser; Supplier Part Number 1: 603-AC0402FR-07162KL; Tolerance: 1%; Voltage Rating: 50V; Width: 0.02inch
R20 = AC0402FR-0710K7L: RES 0402 (1005) 10.7k 1% 0.125W 50V Thick Film; Manufacturer: Yageo; Max Power Dissipation: 63mW; Power Rating: 62.5mW; Resistance: 10.7kR; Temperature Coefficient: 100ppm/°C; Case Code (Imperial): 0402; Case Code (Metric): 1005; Case/Package: 0402; Category: Chip SMD Resistors; Component Kind: Standard; ComponentLink1Description: https://datasheet.ciiva.com/12576/pyu-ac-51-rohs-l-2-12576588.pdf?src-supplier=Mouser; ComponentLink1URL: https://datasheet.ciiva.com/12576/pyu-ac-51-rohs-l-2-12576588.pdf?src-supplier=Mouser; Features: Moisture Resistant,Automotive AEC-Q200; Height: 0.015inch; Length: 0.039inch; Library Name: resistors2.IntLib; Manufacturer Part Number: AC0402FR-0710K7L; Max Operating Temperature: 155°C; Min Operating Temperature: -55°C; Number of Terminations: 2; Packaging: Tape and Reel; Pricing 1: 1=0,1, 10=0,01, 50=0,01, 100=0,007, 1000=0,006, 10000=0,002 (USD); RoHS: Yes; RoHS Compliant: Yes; Series: AC; Signal Integrity: Res; Simulation: RESISTOR; Stock 1: 37509; Supplier 1: Mouser; Supplier Part Number 1: 603-AC0402FR-0710K7L; Termination: SMD/SMT; Tolerance: 1%; Voltage Rating: 50V; Weight: 2.3E-05oz; Width: 0.5mm
R22 = RC0402FR-07113KL: RES 0402 (1005) 113.0k 1% 0.0625W 50V Thick Film; Manufacturer: Yageo; Category: Chip SMD Resistors; Component Kind: Standard; ComponentLink1Description: https://datasheet.ciiva.com/16744/pyu-rc0402-51-rohs-l-6-r-16744521.pdf?src-supplier=Future+Electronics; ComponentLink1URL: https://datasheet.ciiva.com/16744/pyu-rc0402-51-rohs-l-6-r-16744521.pdf?src-supplier=Future+Electronics; ComponentLink2Description: https://datasheet.ciiva.com/2452/pyu-r-int-thick-7-2452604.pdf?src-supplier=Digikey; ComponentLink2URL: https://datasheet.ciiva.com/2452/pyu-r-int-thick-7-2452604.pdf?src-supplier=Digikey; Lead Free: 5697LeadFree; Library Name: resistors2.IntLib; Manufacturer Part Number: RC0402FR-07113KL; Pricing 1: 1=0,1, 10=0,007, 50=0,007, 100=0,004, 1000=0,003, 10000=0,002 (USD); RoHS: Yes; Signal Integrity: Res; Simulation: RESISTOR; Stock 1: 42603; Supplier 1: Mouser; Supplier Part Number 1: 603-RC0402FR-07113KL; Voltage Rating (DC): 50V
R25 = RC0402FR-0716K9L: RES 0402 (1005) 16.9k 1% 0.0625W 50V Thick Film; Manufacturer: Yageo; Max Power Dissipation: 63mW; Power Rating: 62.5mW; Resistance: 16.9kR; Temperature Coefficient: 100ppm/°C; Case Code (Imperial): 0402; Case Code (Metric): 1005; Case/Package: 0402; Category: Chip SMD Resistors; Component Kind: Standard; ComponentLink1Description: https://datasheet.ciiva.com/12561/pyu-rc0402-51-rohs-l-6-r-8721-12561757.pdf?src-supplier=Mouser; ComponentLink1URL: https://datasheet.ciiva.com/12561/pyu-rc0402-51-rohs-l-6-r-8721-12561757.pdf?src-supplier=Mouser; ComponentLink2Description: https://datasheet.ciiva.com/17073/pyu-rc0402-51-rohs-l-6-r-17073310.pdf?src-supplier=Future+Electronics; ComponentLink2URL: https://datasheet.ciiva.com/17073/pyu-rc0402-51-rohs-l-6-r-17073310.pdf?src-supplier=Future+Electronics; Contact Plating: Tin,Matte; Features: Moisture Resistant; Height: 0.016inch; Lead Free: Lead Free; Length: 0.039inch; Library Name: resistors2.IntLib; Manufacturer Part Number: RC0402FR-0716K9L; Max Operating Temperature: 155°C; Min Operating Temperature: -55°C; Number of Terminations: 2; Package Quantity: 10000; Packaging: Tape and Reel; Pricing 1: 1=0,1, 10=0,008, 50=0,008, 100=0,005, 1000=0,005, 10000=0,002 (USD); RoHS: Yes; RoHS Compliant: Yes; Series: RC; Signal Integrity: Res; Simulation: RESISTOR; Size Code: 0402; Stock 1: 109384; Supplier 1: Mouser; Supplier Part Number 1: 603-RC0402FR-0716K9L; Termination: SMD/SMT; Tolerance: 1%; Voltage Rating: 50V; Voltage Rating (DC): 50V; Width: 0.5mm
U3 = REF3430TIDBVR: 3-V, low-drift, low-power, small-footprint series voltage reference 6-SOT-23 -40 to 125; Manufacturer: Texas Instruments; Category: Voltage References; Component Kind: Standard; Library Name: ics2.IntLib; Manufacturer Part Number: REF3430TIDBVR; Pricing 1: 1=2,54, 10=1,66, 50=1,66, 100=1,46, 1000=1,25, 10000=1,03 (USD); RoHS: No; Stock 1: 13091; Supplier 1: Mouser; Supplier Part Number 1: 595-REF3430TIDBVR
U4 = LM43603AQPWPTQ1: Automotive Qualified 3.5V to 36V, 3A Synchronous Step-Down Voltage Converter 16-HTSSOP -40 to 125; Manufacturer: Texas Instruments; Max Output Voltage: 28V; Min Output Voltage: 1V; Number of Outputs: 1; Output Configuration: Positive; Output Type: Adjustable; Switching Frequency: 2.2MHz; Synchronous Rectifier: Yes; Category: Voltage Regulators - Switching; Component Kind: Standard; ComponentLink2Description: http://www.ti.com/lit/ds/symlink/lm43603-q1.pdf?src-supplier=Digi-Key; ComponentLink2URL: http://www.ti.com/lit/ds/symlink/lm43603-q1.pdf?src-supplier=Digi-Key; ComponentLink3Description: http://www.ti.com/general/docs/suppproductinfo.tsp?distId=10&amp;gotoUrl=http%3A%2F%2Fwww.ti.com%2Flit%2Fgpn%2Flm43603-q1&src-supplier=Digi-Key; ComponentLink3URL: http://www.ti.com/general/docs/suppproductinfo.tsp?distId=10&amp;gotoUrl=http%3A%2F%2Fwww.ti.com%2Flit%2Fgpn%2Flm43603-q1&src-supplier=Digi-Key; Library Name: ics2.IntLib; Manufacturer Part Number: LM43603AQPWPTQ1; Max Input Voltage: 36V; Max Operating Temperature: 125°C; Min Input Voltage: 3.5V; Min Operating Temperature: -40°C; Mount: Surface Mount; Output Current: 3A; Packaging: Tape and Reel; Pricing 1: 1=6,95000, 10=6,24000, 50=5,90000, 100=5,11000, 1000=4,84000, 10000=4,84000 (USD); RoHS: Yes; RoHS Compliant: Yes; Supplier 1: Mouser; Supplier Part Number 1: 595-LM43603AQPWPTQ1
U5 U6 = TPS7B8601QDDARQ1: Automotive 500-mA, 40-V, ultra-low-IQ, low-dropout (LDO) linear regulator with power good 8-SO PowerPAD -40 to 150; Manufacturer: Texas Instruments; Category: Integrated Circuits (ICs); Component Kind: Standard; Library Name: ics2.IntLib; Manufacturer Part Number: TPS7B8601QDDARQ1; Pricing 1: 1=2,23, 10=1,45, 50=1,45, 100=1,28, 1000=1,09, 10000=1,02 (USD); RoHS: Yes; RoHS Compliant: Yes; Stock 1: 7087; Supplier 1: Mouser; Supplier Part Number 1: 595-TPS7B8601QDDARQ1
U7 = LM7705MME/NOPB: IC SW CAP/INV -230MV 20MA 8MSOP; Manufacturer: TI National Semiconductor; Category: Voltage Regulators - Switching; Component Kind: Standard; ComponentLink1Description: https://datasheet.ciiva.com/3215/lm7705-3215705.pdf?src-supplier=Avnet; ComponentLink1URL: https://datasheet.ciiva.com/3215/lm7705-3215705.pdf?src-supplier=Avnet; ComponentLink2Description: https://datasheet.ciiva.com/6579/lm7705-6579829.pdf?src-supplier=Digikey; ComponentLink2URL: https://datasheet.ciiva.com/6579/lm7705-6579829.pdf?src-supplier=Digikey; ComponentLink3Description: https://datasheet.ciiva.com/6579/lm7705-6579830.pdf?src-supplier=Digikey; ComponentLink3URL: https://datasheet.ciiva.com/6579/lm7705-6579830.pdf?src-supplier=Digikey; Library Name: ics2.IntLib; Manufacturer Part Number: LM7705MME/NOPB; Pricing 1: 1=0,71, 10=0,448, 50=0,448, 100=0,389, 1000=0,377, 10000=0,377 (USD); RoHS: Yes; Stock 1: 2332; Supplier 1: Mouser; Supplier Part Number 1: 926-LM7705MME/NOPB; Thickness: 970um
